# Altium schematic sheet Power_supply.SchDoc(Power_supply)
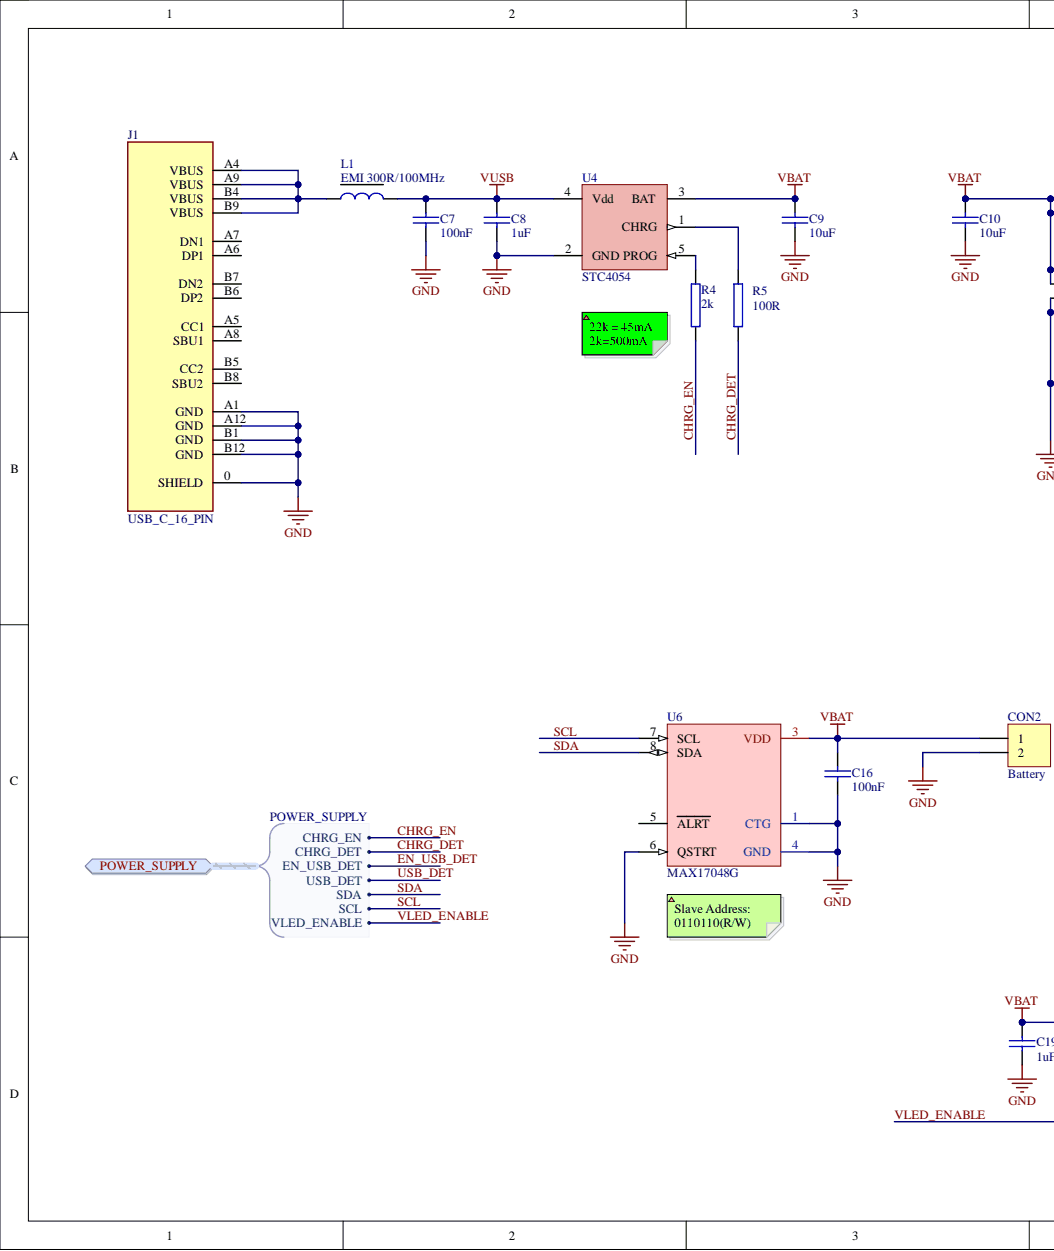
[diagram p0: Power_supply.SchDoc, left]
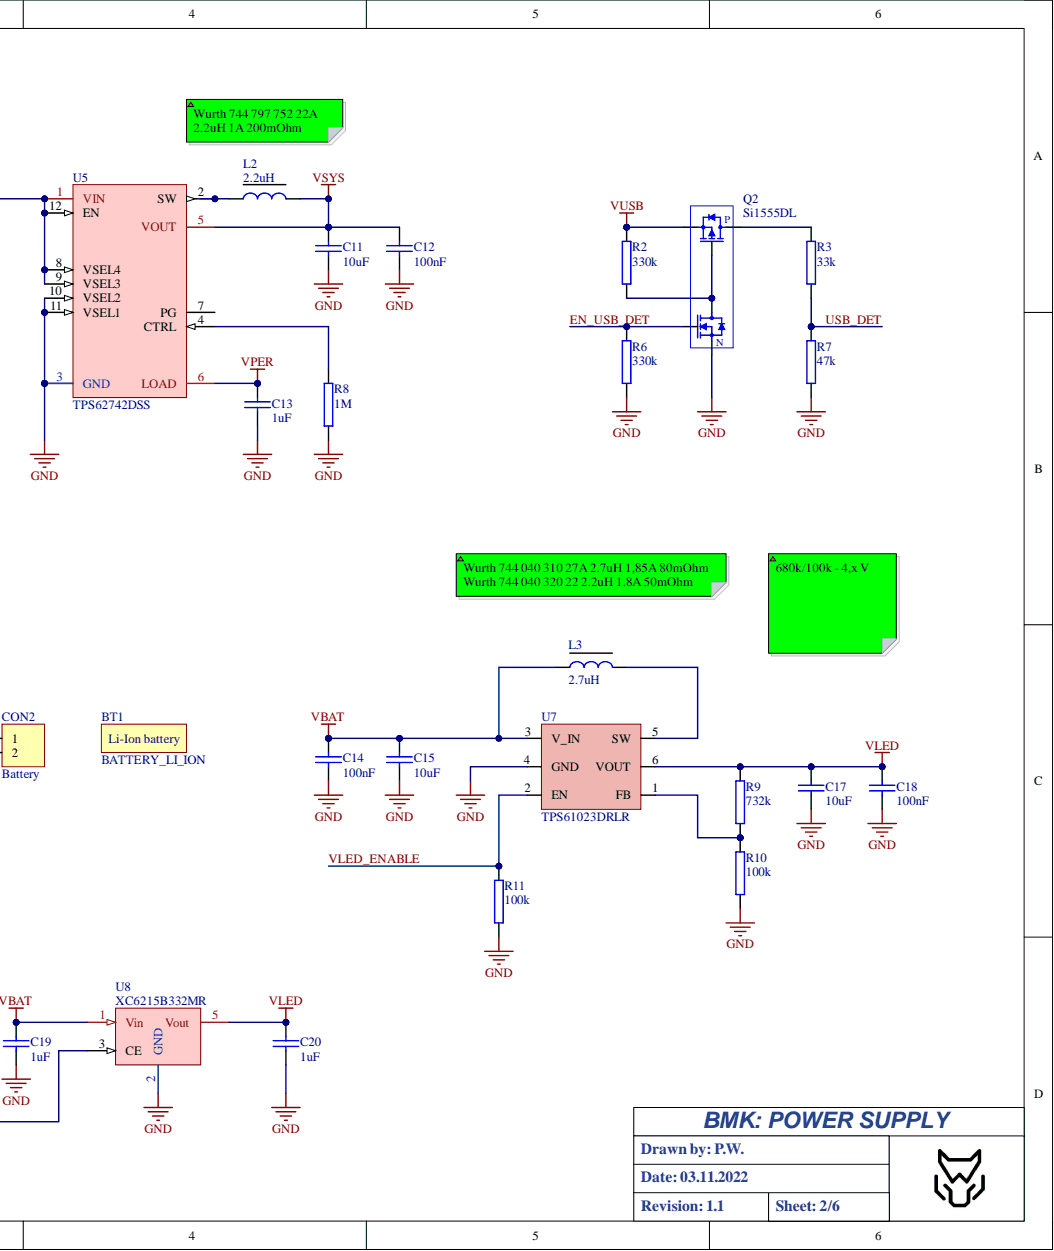
[diagram p1: Power_supply.SchDoc, right]

## Parts
BT1  BATTERY_LI_ION  [LI-ion battery 1100mAh]
C7  100nF  [C0603L]
C8  1uF  [C0603L]
C9  10uF  [C0603L]
C10  10uF  [C0603L]
C11  10uF  [C0603L]
C12  100nF  [C0603L]
C13  1uF  [C0603L]
C14  100nF  [C0603L]
C15  10uF  [C0603L]
C16  100nF  [C0603L]
C17  10uF  [C0603L]
C18  100nF  [C0603L]
C19  1uF  [C0603L]
C20  1uF  [C0603L]
CON2  Battery  [HDR1X2_1mm27]
J1  USB_C_16_PIN  [USB_C_16_PIN]
L1  EMI 300R/100MHz  [L0805]
L2  2.2uH  [L0805]
L3  2.7uH  [DL3x3]
Q2  Si1555DL  [SOT-363]
R2  330k  [1608[0603]]
R3  33k  [1608[0603]]
R4  2k  [1608[0603]]
R5  100R  [1608[0603]]
R6  330k  [1608[0603]]
R7  47k  [1608[0603]]
R8  1M  [1608[0603]]
R9  732k  [1608[0603]]
R10  100k  [1608[0603]]
R11  100k  [1608[0603]]
U4  STC4054  [SOT23-5]
U5  TPS62742DSS  [R-PWSON-N12_2]
U6  MAX17048G  [TDFN8_2x2x075]
U7  TPS61023DRLR  [SOTFL50P160X60-6N]
U8  XC6215B332MR  [SOT23-5]

## Ports
POWER_SUPPLY, POWER_SUPPLY.CHRG_DET, POWER_SUPPLY.CHRG_EN, POWER_SUPPLY.EN_USB_DET, POWER_SUPPLY.SCL, POWER_SUPPLY.SDA, POWER_SUPPLY.USB_DET, POWER_SUPPLY.VLED_ENABLE

## Nets
GND: C7.2 C8.2 C9.2 C10.2 C11.2 C12.2 C13.2 C14.2 C15.2 C16.2 C17.2 C18.2 C19.2 C20.2 CON2.2 J1.0(SHIELD) J1.A1(GND) J1.A12(GND) J1.B1(GND) J1.B12(GND) Q2.1 R6.1 R7.1 R8.1 R10.1 R11.1 U4.2(GND) U5.3(GND) U5.10(VSEL2) U5.11(VSEL1) U5.13 U6.1(CTG) U6.4(GND) U6.6(QSTRT) U7.4(GND) U8.2(GND)
VBAT: C9.1 C10.1 C14.1 C15.1 C16.1 C19.1 CON2.1 L3.1 U4.3(BAT) U5.1(VIN) U5.8(VSEL4) U5.9(VSEL3) U5.12(EN) U6.3(VDD) U7.3(V_IN) U8.1(Vin)
VLED: C17.1 C18.1 C20.1 R9.2 U7.6(VOUT) U8.5(Vout)
VPER: C13.1 U5.6(LOAD)
VSYS: C11.1 C12.1 L2.2 U5.5(VOUT)
VUSB: C7.1 C8.1 L1.2 Q2.4 R2.2 U4.4(Vdd)
CHRG_DET: R5.1 ; port POWER_SUPPLY
CHRG_EN: R4.1 ; port POWER_SUPPLY
EN_USB_DET: Q2.2 R6.2 ; port POWER_SUPPLY
SCL: U6.7(SCL) ; port POWER_SUPPLY
SDA: U6.8(SDA) ; port POWER_SUPPLY
USB_DET: R3.1 R7.2 ; port POWER_SUPPLY
VLED_ENABLE: R11.2 U7.2(EN) U8.3(CE) ; port POWER_SUPPLY
NetJ1_A4: J1.A4(VBUS) J1.A9(VBUS) J1.B4(VBUS) J1.B9(VBUS) L1.1
NetL2_1: L2.1 U5.2(SW)
NetL3_2: L3.2 U7.5(SW)
NetQ2_3: Q2.3 R3.2
NetQ2_5: Q2.5 Q2.6 R2.1
NetR4_2: R4.2 U4.5(PROG)
NetR5_2: R5.2 U4.1(CHRG)
NetR8_2: R8.2 U5.4(CTRL)
NetR9_1: R9.1 R10.2 U7.1(FB)

Not connected: J1 A5(CC1) A6(DP1) A7(DN1) A8(SBU1) B5(CC2) B6(DP2) B7(DN2) B8(SBU2); U5 7(PG); U6 5(ALRT)

## Library data of the parts
BT1 = BATTERY_LI_ION: Battery; Component Kind: Standard; Library Name: LIB_PW.IntLib
C7-C20 = CAP: Capacitor; Component Kind: Standard
  C7-C13 C16 C19 C20: Library Name: LIB_PW.IntLib
  C14 C15 C17 C18: Library Name: SCH_PW.SCHLIB
CON2 = Header 2: Header, 2-Pin; Component Kind: Standard; LatestRevisionDate: 17-Jul-2002; LatestRevisionNote: Re-released for DXP Platform.; Library Name: LIB_PW.IntLib; Publisher: Altium Limited
J1 = USB_C_16_PIN: USB-C; MF: Amphenol ICC; MP: 12401598E4#2A; Availability: Unavailable; Component Kind: Standard; Library Name: LIB_PW.IntLib; Package: None; Price: None; SnapEDA_Link: https://www.snapeda.com/parts/12401598E4%232A/Amphenol%20FCI/view-part/2762372/?ref=snap
L1-L3 = INDUCTOR: Component Kind: Standard
  L1 L2: Library Name: SCH_PW.SCHLIB
  L3: Library Name: LIB_PW.IntLib
Q2 = Si1555DL: Montage: SMD; Component Kind: Standard; ComponentLink1Description: Datasheet; ComponentLink1URL: https://www.diodes.com/assets/Datasheets/ds30380.pdf; Library Name: LIB_PW.IntLib
R2-R11 = RES: Component Kind: Standard
  R2-R8 R11: Library Name: LIB_PW.IntLib
  R9 R10: Library Name: SCH_PW.SCHLIB
U4 = STC4054: Li-on 800mA linear battery charger; Component Kind: Standard; Library Name: LIB_PW.IntLib
U5 = TPS62742DSS: Step Down Converter For Low Power Applications; Component Kind: Standard; Library Name: LIB_PW.IntLib
U6 = MAX17048G: 1-Cell/2-Cell Fuel Gauge with ModelGauge; Component Kind: Standard; Library Name: LIB_PW.IntLib
U7 = TPS61023DRLR: 3.7-A Boost Converter with 0.5-V Ultra-low Input Voltage; Component Kind: Standard; Library Name: SCH_PW.SCHLIB
U8 = XC6215BxxxMR: Low Power Voltage Regulator with ON/OFF Switch; Component Kind: Standard; Library Name: LIB_PW.IntLib
